# Altium schematic sheet 08_spiLevelShift.SchDoc
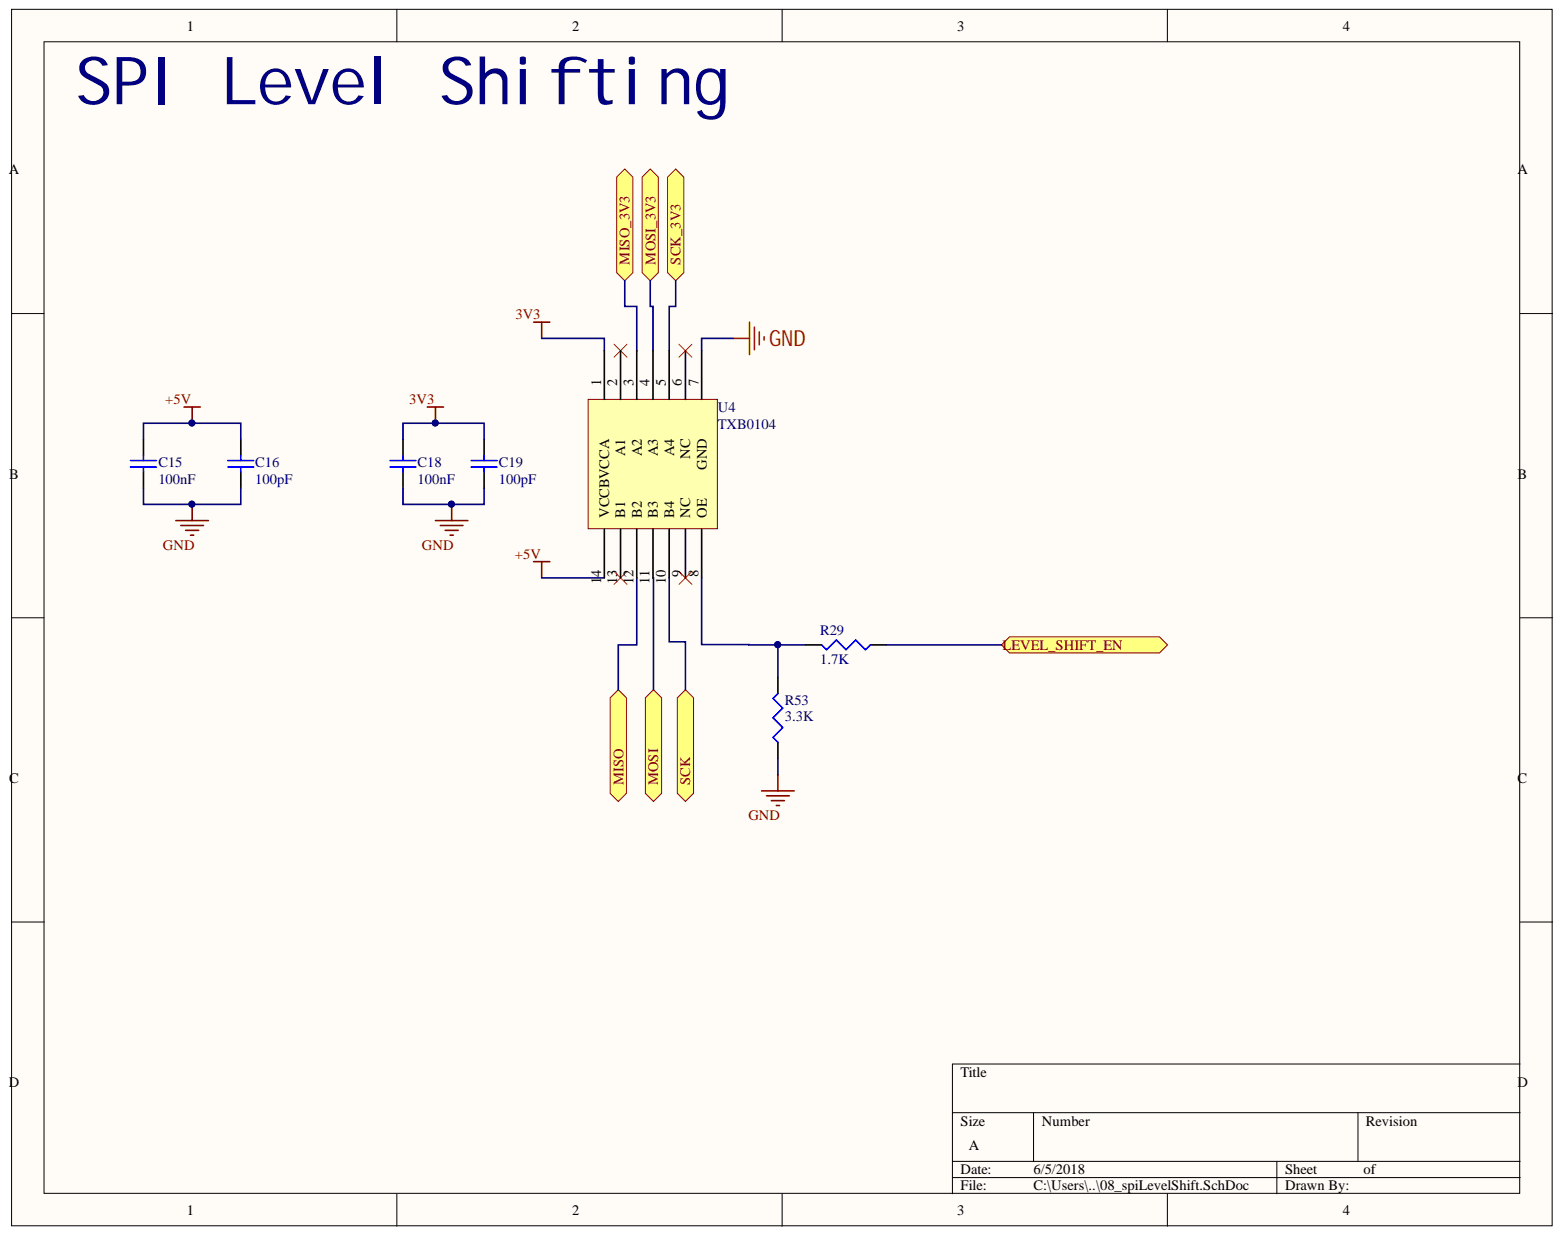
[diagram p0: 08_spiLevelShift.SchDoc]

## Parts
C15  100nF  [CAP_0603_SMD]
C16  100pF  [CAP_0603_SMD]
C18  100nF  [CAP_0603_SMD]
C19  100pF  [CAP_0603_SMD]
R29  1.7K  [RES_0603_SMD]
R53  3.3K  [RES_0603_SMD]
U4  TXB0104  [SOP65P640-14L]

## Ports
LEVEL_SHIFT_EN, MISO, MISO_3V3, MOSI, MOSI_3V3, SCK, SCK_3V3

## Nets
3V3: C18.1 C19.1 U4.1
+5V: C15.1 C16.1 U4.14
GND: C15.2 C16.2 C18.2 C19.2 R53.1 U4.7
LEVEL_SHIFT_EN: R29.1 ; port LEVEL_SHIFT_EN
MISO: U4.12(B2) ; port MISO
MISO_3V3: U4.3 ; port MISO_3V3
MOSI: U4.11(B3) ; port MOSI
MOSI_3V3: U4.4 ; port MOSI_3V3
SCK: U4.10(B4) ; port SCK
SCK_3V3: U4.5 ; port SCK_3V3
NetR29_2: R29.2 R53.2 U4.8(OE)

Not connected: U4 2 6 9(NC) 13(B1)

## Library data of the parts
C15 C16 C18 C19 = Cap Semi: Capacitor (Semiconductor SIM Model); Component Kind: Standard; LatestRevisionDate: 29-May-2009; LatestRevisionNote: IPC-7351 Footprint Added.; PackageDescription: Chip Capacitor; PackageReference: SMD 0805; Published: 8-Jun-2000; Signal Integrity: Cap Semi; Simulation: CAP; Library Name: C:\Users\Public\Documents\Altium\AD16\Library\Miscellaneous Devices.IntLib; Publisher: Altium Limited
  C15 C18: Value: 100nF
  C16 C19: Value: 100pF
R29 R53 = Res3: Resistor; Component Kind: Standard; LatestRevisionDate: 29-May-2009; LatestRevisionNote: IPC-7351 Footprint Added.; PackageDescription: Chip Resistor; PackageReference: SMD 0805; Published: 8-Jun-2000; Signal Integrity: Res; Simulation: RESISTOR; Library Name: C:\Users\Public\Documents\Altium\AD16\Library\Miscellaneous Devices.IntLib; Publisher: Altium Limited
  R29: Value: 1.7K
  R53: Value: 3.3K
U4 = TXB0104: Component Kind: Standard; Library Name: ATmega2560_Library.SchLib
